# Altium schematic sheet audio.SchDoc
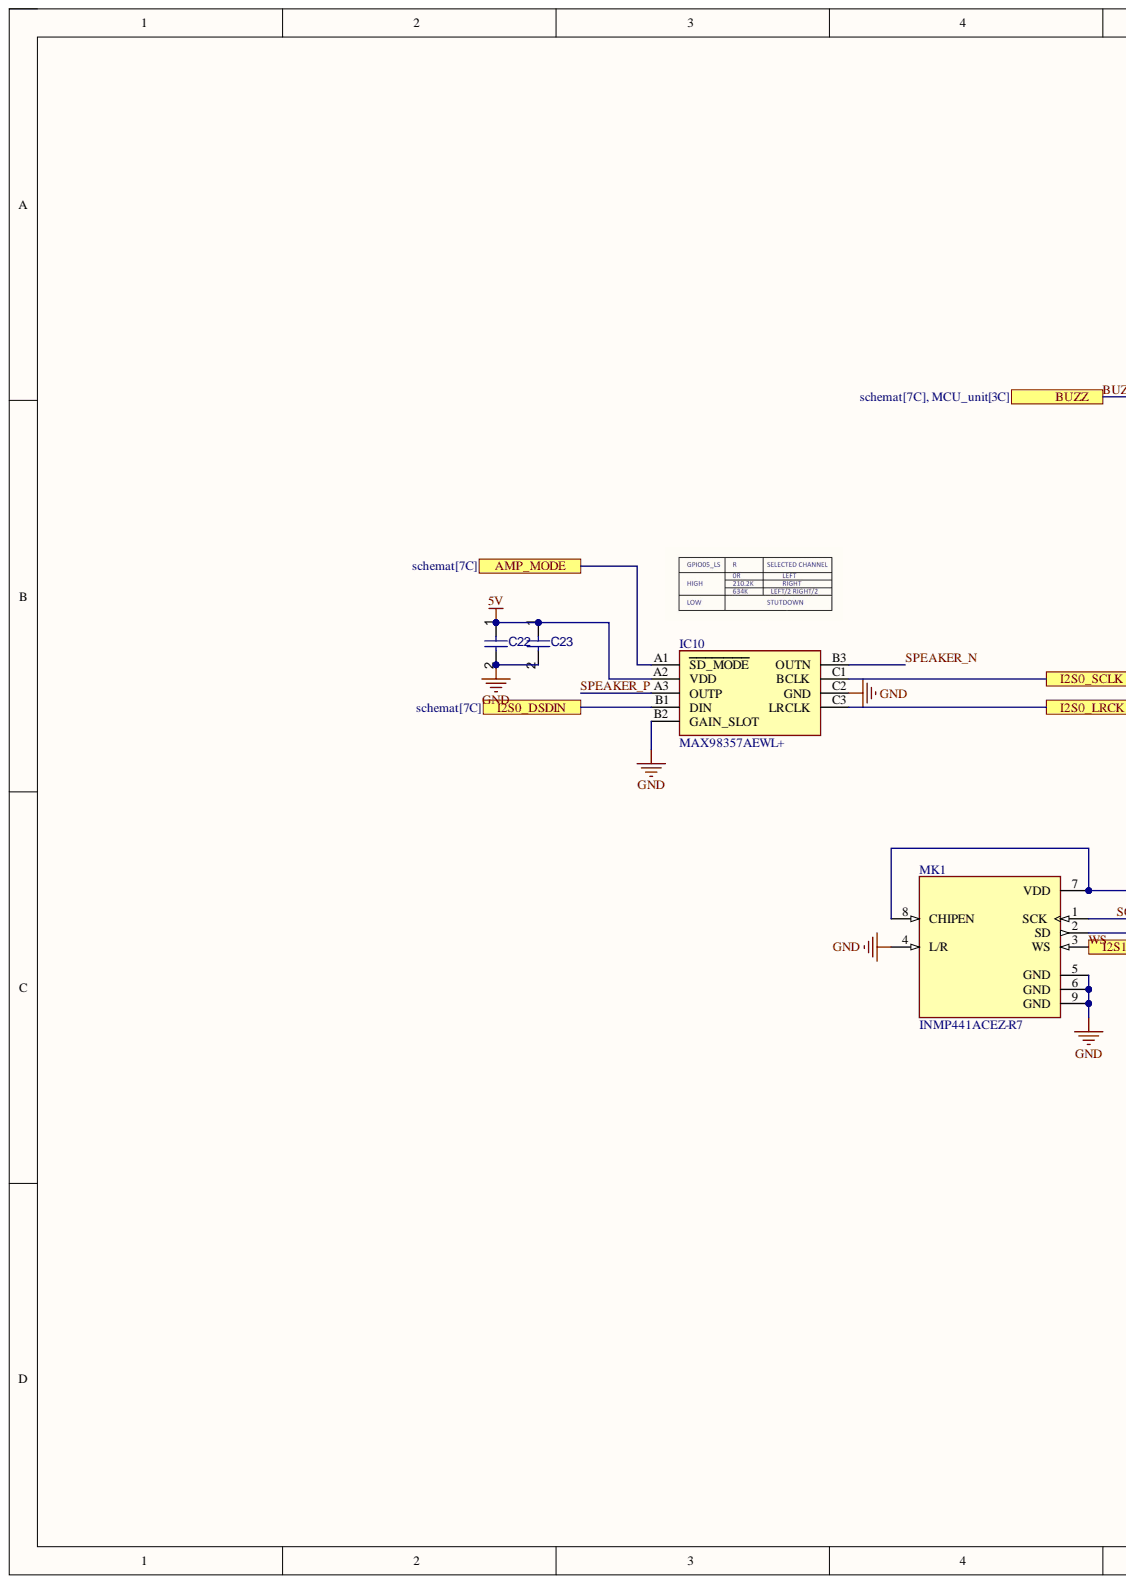
[diagram p0: audio.SchDoc, left]
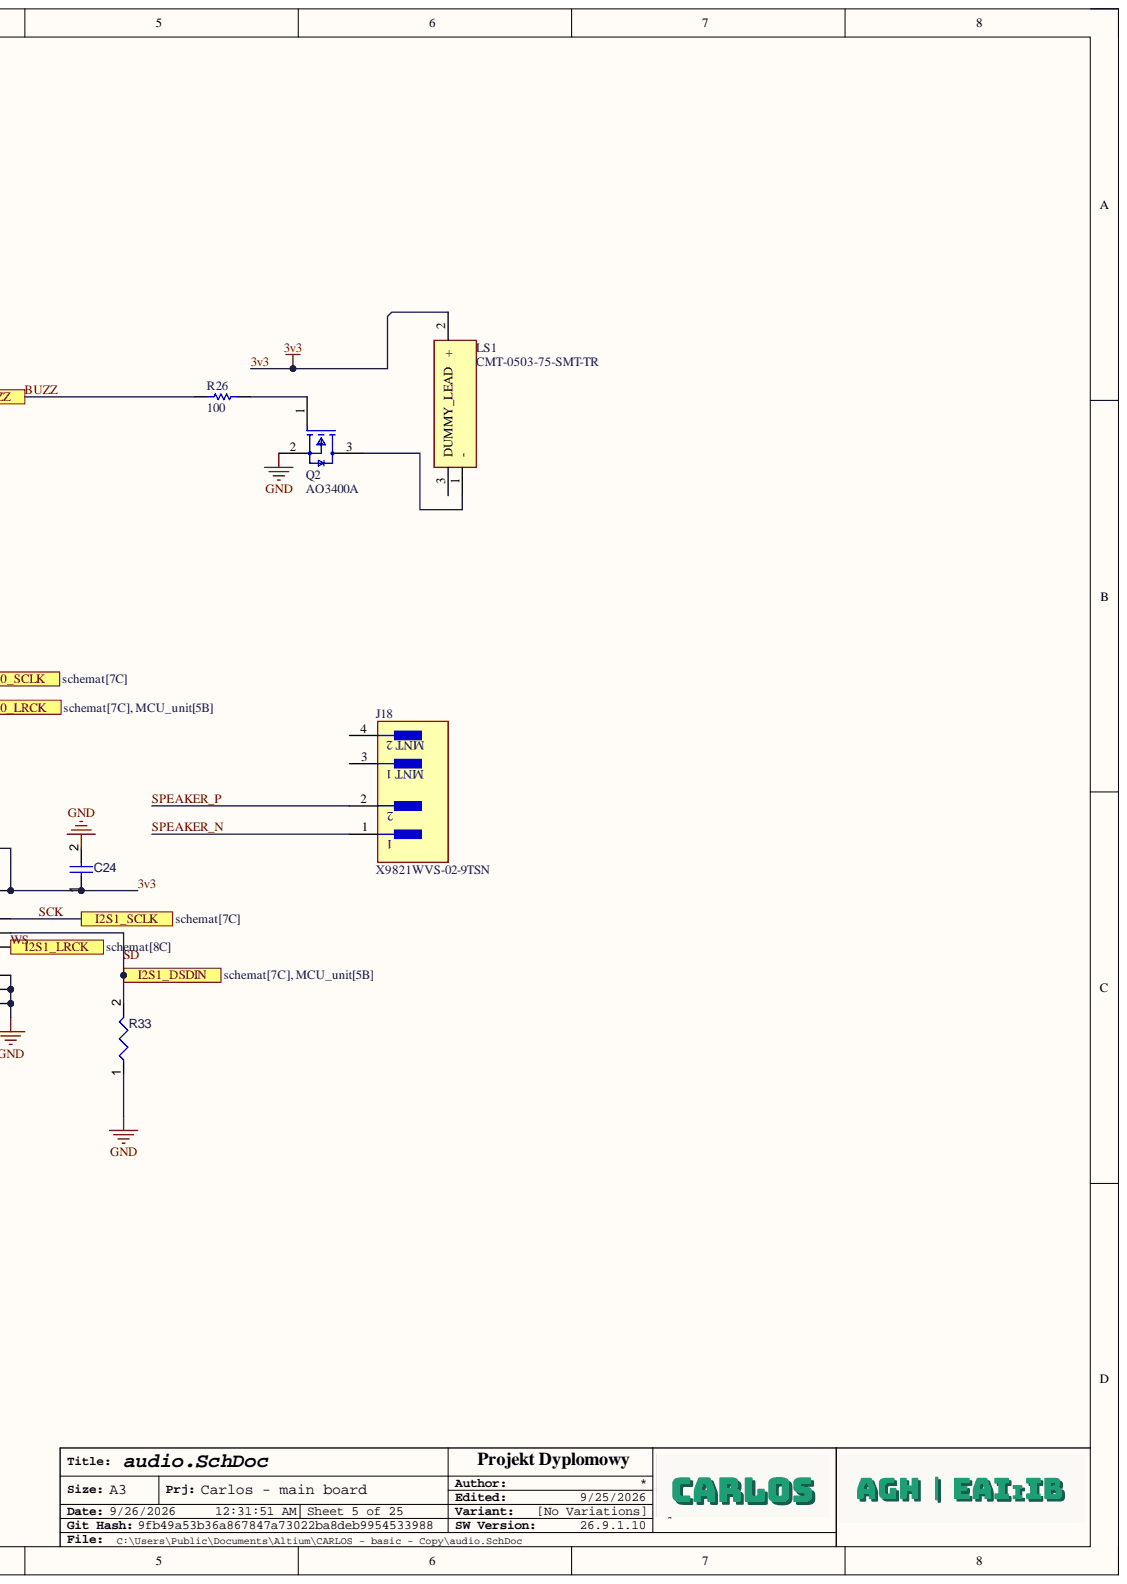
[diagram p1: audio.SchDoc, right]

## Parts
C22  100nF  [0603]  (value not drawn)
C23  100nF  [0603]  (value not drawn)
C24  100nF  [0402]  (value not drawn)
IC10  MAX98357AEWL+  [BGA9C40P3X3_144X135X69]
J18  X9821WVS-02-9TSN  [FP-53398-0267-MFG]
LS1  CMT-0503-75-SMT-TR  [CMT050375SMTTR]
MK1  INMP441ACEZ-R7  [MIC_INMP441ACEZ-R7]
Q2  AO3400A  [FP-SOT23-MFG]
R26  100  [RESC2013X50X30LL20T20]
R33  100k  [0603]  (value not drawn)

## Ports
AMP_MODE, BUZZ, I2S0_DSDIN, I2S0_LRCK, I2S0_SCLK, I2S1_DSDIN, I2S1_LRCK, I2S1_SCLK

## Nets
5V: C22.1 C23.1 IC10.A2(VDD)
GND: C22.2 C23.2 C24.2 IC10.B2(GAIN_SLOT) IC10.C2(GND) MK1.4(L/R) MK1.5(GND) MK1.6(GND) MK1.9(GND) Q2.2 R33.1
3v3: C24.1 LS1.2 MK1.7(VDD) MK1.8(CHIPEN)
AMP_MODE: IC10.A1(SD_MODE) ; port AMP_MODE
BUZZ: R26.1 ; port BUZZ
I2S0_DSDIN: IC10.B1(DIN) ; port I2S0_DSDIN
I2S0_LRCK: IC10.C3(LRCLK) ; port I2S0_LRCK
I2S0_SCLK: IC10.C1(BCLK) ; port I2S0_SCLK
SCK: MK1.1(SCK) ; port I2S1_SCLK
SD: MK1.2(SD) R33.2 ; port I2S1_DSDIN
SPEAKER_N: IC10.B3(OUTN) J18.1
SPEAKER_P: IC10.A3(OUTP) J18.2
WS: MK1.3(WS) ; port I2S1_LRCK
NetLS1_1: LS1.1 Q2.3
NetQ2_1: Q2.1 R26.2

Not connected: J18 3 4; LS1 3

## Library data of the parts
C22-C24 = CMP-001-00083-3: 0402/0603/0805/1206; Capacitor Type: MLCC; Case/Package: 0402/0603/0805/1206; Component Kind: Standard; Library Name: Jakub Sikora
IC10 = MAX98357AEWL+: Integrated Circuit; Manufacturer_Name: Analog Devices; Component Kind: Standard; Datasheet Link: https://componentsearchengine.com/Datasheets/2/MAX98357AEWL+.pdf; Height: 0.69mm; Manufacturer_Part_Number: MAX98357AEWL+; Mouser Part Number: N/A; Mouser Price/Stock: https://www.mouser.co.uk/ProductDetail/Analog-Devices-Maxim-Integrated/MAX98357AEWL%2b?qs=sEr1OoMjgSBif2wauSYO7g%3D%3D
J18 = CMP-04776-000270-1: CONN HEADER SMD 2POS 1.25MM; Contact Resistance: 10mR; Gender: Male; Max Current Rating: 8A; Max Voltage Rating (DC): 600V; Number of Contacts: 2; Number of Positions: 6; Component Kind: Standard; Contact Gender: Male; Contact Material: Bronze; Contact Plating: Tin; Height: 12.8mm; Housing Color: Natural; Housing Material: Nylon; Length: 18mm; Library Name: Altium Content Vault; Max Operating Temperature: 105°C; Min Operating Temperature: -40°C; Mount: Through Hole; Number of Rows: 1; Orientation: Straight; Package Quantity: 1000; Packaging: Tape and Reel; Pitch: 1.25mm; Radiation Hardening: No; RoHS Compliant: Yes; Series: 53398; Termination: SMD/SMT
LS1 = CMT-0503-75-SMT-TR: Loudspeaker or Buzzer; Manufacturer_Name: Same Sky; Component Kind: Standard; Datasheet Link: https://www.sameskydevices.com/product/resource/CMT-0503-75-SMT-TR.pdf; Height: 3.5mm; Manufacturer_Part_Number: CMT-0503-75-SMT-TR; Mouser Part Number: 490-CMT-0503-75SMTTR; Mouser Price/Stock: https://www.mouser.co.uk/ProductDetail/CUI-Devices/CMT-0503-75-SMT-TR?qs=P1JMDcb91o5xeUHZ%2F4QPiw%3D%3D
MK1 = INMP441ACEZ-R7: 60 Hz ~ 15 kHz Digital, I2S Microphone MEMS (Silicon) 1.62 V ~ 3.63 V Omnidirectional (-26dB Â±3dB @ 94dB SPL) Solder Pads; MF: TDK InvenSense; Check_prices: https://www.snapeda.com/parts/INMP441ACEZ-R7/TDK/view-part/?ref=eda; Component Kind: Standard; Library Name: INMP441ACEZ-R7.IntLib; MP: INMP441ACEZ-R7; Package: LGA-9 TDK InvenSense; SnapEDA_Link: https://www.snapeda.com/parts/INMP441ACEZ-R7/TDK/view-part/?ref=snap
Q2 = CMP-83955-000006-1: MOSFET N-CH 30V 5.7A SOT23; Gate to Source Voltage (Vgs): 12V; Input Capacitance: 630pF; Max Power Dissipation: 1.4W; Number of Elements: 1; Rds On Max: 26.5mR; Case/Package: SOT-23; Component Kind: Standard; Continuous Drain Current (ID): 5.7A; Drain to Source Voltage (Vdss): 30V; Lead Free: Lead Free; Library Name: Altium Content Vault; Max Operating Temperature: 150°C; Min Operating Temperature: -55°C; Mount: Surface Mount; Number of Pins: 3; Packaging: Tape and Reel; Power Dissipation: 1.4W; Radiation Hardening: No
R26 = CMP-2001-04896-1: Manufacturer: Vishay Dale; Part Number: CRCW08050000Z0EA; Component Kind: Standard; Library Name: Altium Content Vault
R33 = CMP-009-00177-1: 0402/0603/0805/1206/1210/2512; Component Kind: Standard; Library Name: Jakub Sikora
